# Altium schematic sheet pixracer_fmu.SchDoc(pixracer_fmu)
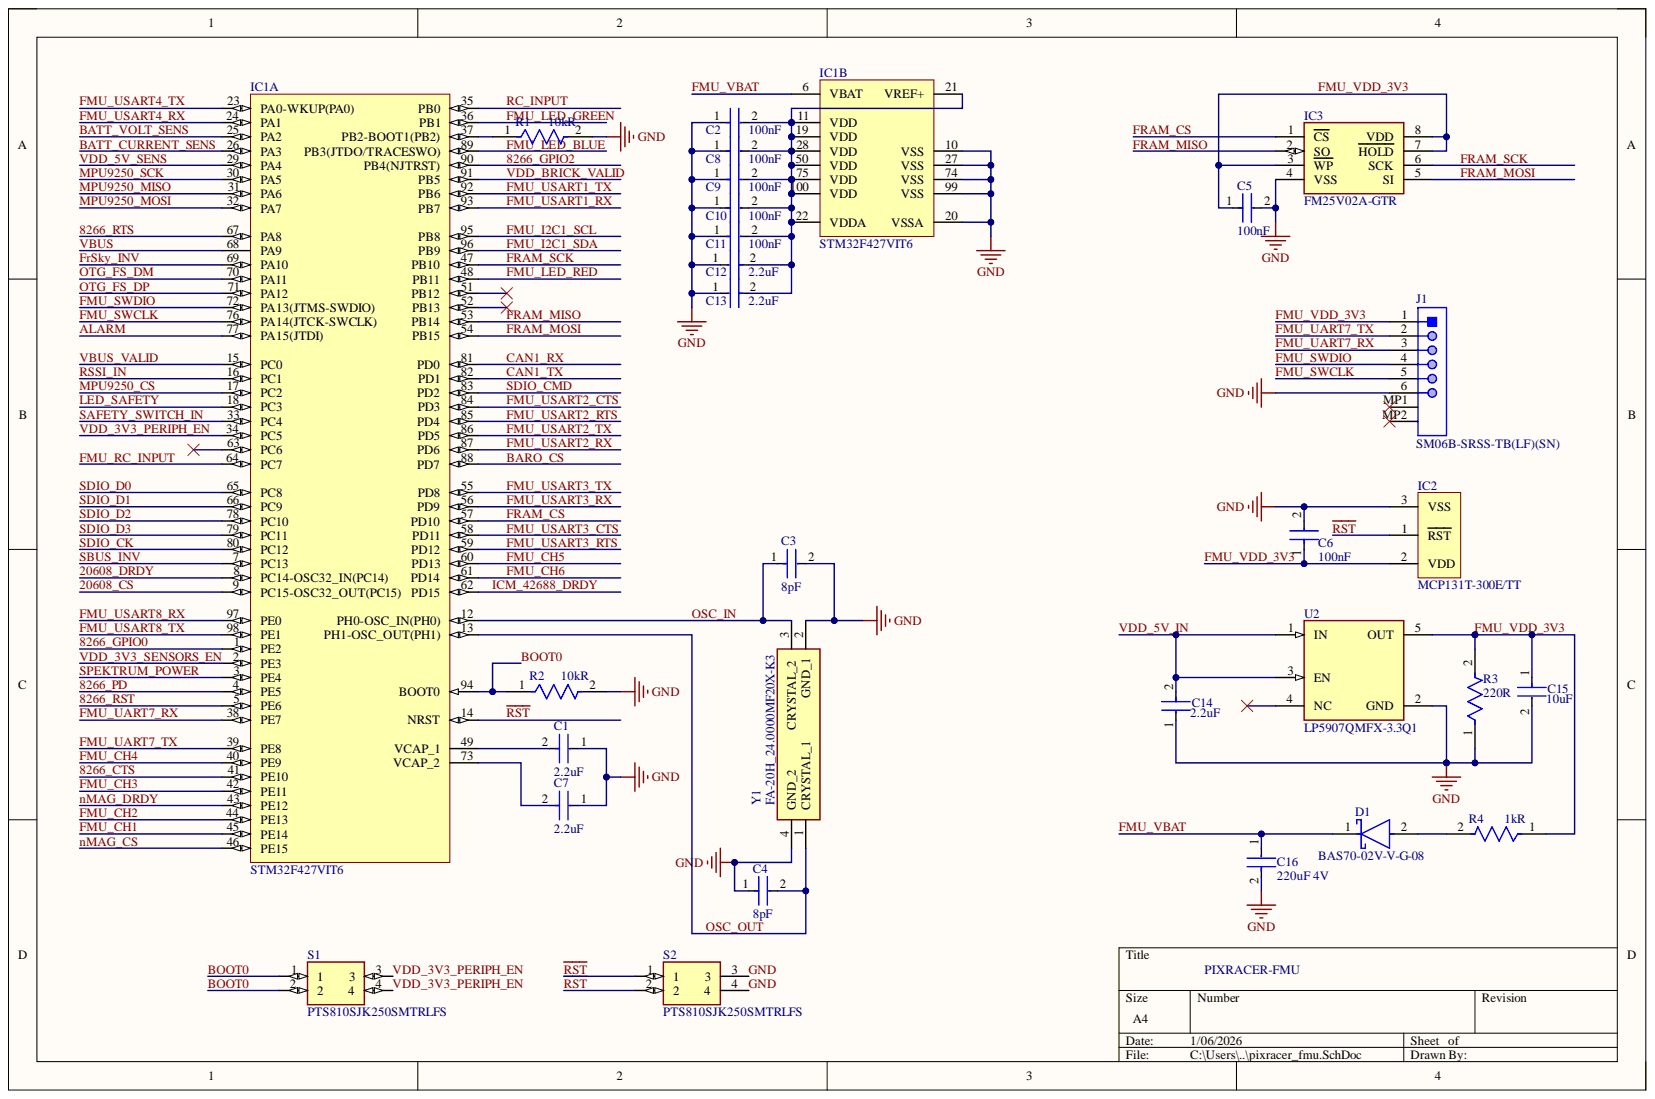
[diagram p0: pixracer_fmu.SchDoc]

## Parts
C1  Capacitance: 2.2uF  [FP-C1608-080-0_1-MFG]  (hidden comment: C1608X7R1A225K080AC)
C2  Capacitance: 100nF  [FP-0603-L_1_6_0_15-W_0_81-IPC_B]  (hidden comment: 0603ZC104MAT2A)
C3  Capacitance: 8pF  [FP-CGA3E-0_3-0_1-IPC_A]  (hidden comment: CGA3E2C0G1H080D080AA)
C4  Capacitance: 8pF  [FP-CGA3E-0_3-0_1-IPC_A]  (hidden comment: CGA3E2C0G1H080D080AA)
C5  Capacitance: 100nF  [FP-0603-L_1_6_0_15-W_0_81-IPC_B]  (hidden comment: 0603ZC104MAT2A)
C6  Capacitance: 100nF  [FP-0603-L_1_6_0_15-W_0_81-IPC_B]  (hidden comment: 0603ZC104MAT2A)
C7  Capacitance: 2.2uF  [FP-C1608-080-0_1-MFG]  (hidden comment: C1608X7R1A225K080AC)
C8  Capacitance: 100nF  [FP-0603-L_1_6_0_15-W_0_81-IPC_B]  (hidden comment: 0603ZC104MAT2A)
C9  Capacitance: 100nF  [FP-0603-L_1_6_0_15-W_0_81-IPC_B]  (hidden comment: 0603ZC104MAT2A)
C10  Capacitance: 100nF  [FP-0603-L_1_6_0_15-W_0_81-IPC_B]  (hidden comment: 0603ZC104MAT2A)
C11  Capacitance: 100nF  [FP-0603-L_1_6_0_15-W_0_81-IPC_B]  (hidden comment: 0603ZC104MAT2A)
C12  Capacitance: 2.2uF  [FP-C1608-080-0_1-MFG]  (hidden comment: C1608X7R1A225K080AC)
C13  Capacitance: 2.2uF  [FP-C1608-080-0_1-MFG]  (hidden comment: C1608X7R1A225K080AC)
C14  Capacitance: 2.2uF  [FP-C1608-080-0_1-MFG]  (hidden comment: C1608X7R1A225K080AC)
C15  Capacitance: 10uF  [FP-CL10-IPC_B]  (hidden comment: CL10A106KP8NNND)
C16  GRM31CR60G227ME11L  [FP-GRM31C-0_3-e0_3_0_9-IPC_C]  (value not drawn)
D1  BAS70-02V-V-G-08  [FP-S8-V-3880_02-001-MFG]
IC1  STM32F427VIT6  [STM-LQFP100_N]  (parts A,B)
IC2  MCP131T-300E/TT  [SOT-23-TT3_N]
IC3  FM25V02A-GTR  [SOIC127P602X173-8N]
J1  SM06B-SRSS-TB(LF)(SN)  [JST-SM06B-SRSS-TB_V]
R1  Resistance: 10kR  [FP-ERJ3G-IPC_C]  (hidden comment: ERJ-3GEYJ103V)
R2  Resistance: 10kR  [FP-ERJ3G-IPC_C]  (hidden comment: ERJ-3GEYJ103V)
R3  Resistance: 220R  [FP-CRCW0603-e3-MFG]  (hidden comment: CRCW0603220RFKEB)
R4  Resistance: 1kR  [FP-RC1608-IPC_A]  (hidden comment: RC1608J102CS)
S1  PTS810SJK250SMTRLFS  [PTS810SJG250SMTRLFS]
S2  PTS810SJK250SMTRLFS  [PTS810SJG250SMTRLFS]
U2  LP5907QMFX-3.3Q1  [FP-DBV0005A-MFG]
Y1  FA-20H_24.0000MF20X-K3  [FA20H160000MF12ZAC3]

## Nets
8266_CTS: IC1.41(PE10)
8266_GPIO0: IC1.1(PE2)
8266_GPIO2: IC1.90(PB4(NJTRST))
8266_PD: IC1.4(PE5)
8266_RST: IC1.5(PE6)
8266_RTS: IC1.67(PA8)
20608_CS: IC1.9(PC15-OSC32_OUT(PC15))
20608_DRDY: IC1.8(PC14-OSC32_IN(PC14))
~{RST}: IC1.14(NRST) IC2.1 S2.1 S2.2
ALARM: IC1.77(PA15(JTDI))
BARO_CS: IC1.88(PD7)
BATT_CURRENT_SENS: IC1.26(PA3)
BATT_VOLT_SENS: IC1.25(PA2)
BOOT0: IC1.94(BOOT0) R2.1 S1.1 S1.2
CAN1_RX: IC1.81(PD0)
CAN1_TX: IC1.82(PD1)
FMU_CH1: IC1.45(PE14)
FMU_CH2: IC1.44(PE13)
FMU_CH3: IC1.42(PE11)
FMU_CH4: IC1.40(PE9)
FMU_CH5: IC1.60(PD13)
FMU_CH6: IC1.61(PD14)
FMU_I2C1_SCL: IC1.95(PB8)
FMU_I2C1_SDA: IC1.96(PB9)
FMU_LED_BLUE: IC1.89(PB3(JTDO/TRACESWO))
FMU_LED_GREEN: IC1.36(PB1)
FMU_LED_RED: IC1.48(PB11)
FMU_RC_INPUT: IC1.64(PC7)
FMU_SWCLK: IC1.76(PA14(JTCK-SWCLK)) J1.5
FMU_SWDIO: IC1.72(PA13(JTMS-SWDIO)) J1.4
FMU_UART7_RX: IC1.38(PE7) J1.3
FMU_UART7_TX: IC1.39(PE8) J1.2
FMU_USART1_RX: IC1.93(PB7)
FMU_USART1_TX: IC1.92(PB6)
FMU_USART2_CTS: IC1.84(PD3)
FMU_USART2_RTS: IC1.85(PD4)
FMU_USART2_RX: IC1.87(PD6)
FMU_USART2_TX: IC1.86(PD5)
FMU_USART3_CTS: IC1.58(PD11)
FMU_USART3_RTS: IC1.59(PD12)
FMU_USART3_RX: IC1.56(PD9)
FMU_USART3_TX: IC1.55(PD8)
FMU_USART4_RX: IC1.24(PA1)
FMU_USART4_TX: IC1.23(PA0-WKUP(PA0))
FMU_USART8_RX: IC1.97(PE0)
FMU_USART8_TX: IC1.98(PE1)
FMU_VBAT: C16.1 D1.1 IC1.6(VBAT)
FMU_VDD_3V3: C5.1 C6.1 C15.1 IC2.2 IC3.3(WP) IC3.7(HOLD) IC3.8(VDD) J1.1 R3.2 R4.1 U2.5(OUT)
FRAM_CS: IC1.57(PD10) IC3.1(CS)
FRAM_MISO: IC1.53(PB14) IC3.2(SO)
FRAM_MOSI: IC1.54(PB15) IC3.5(SI)
FRAM_SCK: IC1.47(PB10) IC3.6(SCK)
FrSky_INV: IC1.69(PA10)
GND: C1.1 C2.1 C3.2 C4.1 C5.2 C6.2 C7.1 C8.1 C9.1 C10.1 C11.1 C12.1 C13.1 C14.1 C15.2 C16.2 IC1.10(VSS) IC1.20(VSSA) IC1.27(VSS) IC1.74(VSS) IC1.99(VSS) IC2.3 IC3.4(VSS) J1.6 R1.2 R2.2 R3.1 S2.3 S2.4 U2.2(GND) Y1.2(GND_1) Y1.4(GND_2)
ICM_42688_DRDY: IC1.62(PD15)
LED_SAFETY: IC1.18(PC3)
MPU9250_CS: IC1.17(PC2)
MPU9250_MISO: IC1.31(PA6)
MPU9250_MOSI: IC1.32(PA7)
MPU9250_SCK: IC1.30(PA5)
nMAG_CS: IC1.46(PE15)
nMAG_DRDY: IC1.43(PE12)
OSC_IN: C3.1 IC1.12(PH0-OSC_IN(PH0)) Y1.3(CRYSTAL_2)
OSC_OUT: C4.2 IC1.13(PH1-OSC_OUT(PH1)) Y1.1(CRYSTAL_1)
OTG_FS_DM: IC1.70(PA11)
OTG_FS_DP: IC1.71(PA12)
RC_INPUT: IC1.35(PB0)
RSSI_IN: IC1.16(PC1)
SAFETY_SWITCH_IN: IC1.33(PC4)
SBUS_INV: IC1.7(PC13)
SDIO_CK: IC1.80(PC12)
SDIO_CMD: IC1.83(PD2)
SDIO_D0: IC1.65(PC8)
SDIO_D1: IC1.66(PC9)
SDIO_D2: IC1.78(PC10)
SDIO_D3: IC1.79(PC11)
SPEKTRUM_POWER: IC1.3(PE4)
VBUS: IC1.68(PA9)
VBUS_VALID: IC1.15(PC0)
VDD_3V3_PERIPH_EN: IC1.34(PC5) S1.3 S1.4
VDD_3V3_SENSORS_EN: IC1.2(PE3)
VDD_5V_IN: C14.2 U2.1(IN) U2.3(EN)
VDD_5V_SENS: IC1.29(PA4)
VDD_BRICK_VALID: IC1.91(PB5)
NetC1_2: C1.2 IC1.49(VCAP_1)
NetC2_2: C2.2 C8.2 C9.2 C10.2 C11.2 C12.2 C13.2 IC1.11(VDD) IC1.19(VDD) IC1.21(VREF+) IC1.22(VDDA) IC1.28(VDD) IC1.50(VDD) IC1.75(VDD) IC1.100(VDD)
NetC7_2: C7.2 IC1.73(VCAP_2)
NetD1_2: D1.2 R4.2
NetIC1_37: IC1.37(PB2-BOOT1(PB2)) R1.1

Not connected: IC1 51(PB12) 52(PB13) 63(PC6); J1 MP1 MP2; U2 4(NC)

## Library data of the parts
C1 C7 C12-C14 = CMP-08246-006835-1: Multilayer Ceramic Capacitors 2.2μF ±10% 10V X7R SMD 0603; Case Code (Imperial): 0603; Case Code (Metric): 1608; Case/Package: 0603; Component Kind: Standard; Construction: Flat; Depth: 0.8mm; Dielectric: X7R; Dielectric Material: Ceramic; Height: 0.8mm; Lead Free: Lead Free; Length: 1.6mm; Library Name: Altium Content Vault; Max Operating Temperature: 125°C; Min Operating Temperature: -55°C; Mount: Surface Mount; Package Quantity: 4000; Packaging: Tape and Reel; REACH SVHC: No SVHC; Resistor Type: Ceramic; RoHS Compliant: Yes; Series: C; Termination: SMD/SMT; Thickness: 0.037inch; Tolerance: 10%; Voltage Rating: 10V; Voltage Rating (DC): 10V; Weight: 7.1E-05oz; Width: 0.031inch
C2 C5 C6 C8-C11 = CMP-2006-04550-2: General Purpose Ceramic Capacitor, 0603, 100nF, 20%, X7R, 15%, 10V; Case Code (Imperial): 0603; Case Code (Metric): 1608; Case/Package: 0603; Component Kind: Standard; Construction: Flat; Depth: 0.81mm; Dielectric: X7R; Lead Free: Lead Free; Lead Pitch: 0.014inch; Length: 1.6mm; Library Name: Altium Content Vault; Max Operating Temperature: 125°C; Min Operating Temperature: -55°C; Mount: Surface Mount; Number of Pins: 2; Package Quantity: 4000; Packaging: Tape and Reel; Radiation Hardening: No; RoHS Compliant: Yes; Series: -; Termination: SMD/SMT; Thickness: 0.035inch; Tolerance: 20%; Voltage Rating: 10V; Voltage Rating (DC): 10V; Width: 0.032inch
C3 C4 = CMP-08246-000516-1: Cap Ceramic 8pF 50V C0G 0.5pF Pad SMD 0603 +125°C Automotive T/R; Case Code (Imperial): 0603; Case Code (Metric): 1608; Case/Package: 0603; Component Kind: Standard; Composition: Ceramic; Depth: 0.8mm; Dielectric: C0G; Height: 0.8mm; Length: 1.6mm; Library Name: Altium Content Vault; Max Operating Temperature: 125°C; Min Operating Temperature: -55°C; Mount: Surface Mount; Package Quantity: 4000; Packaging: Tape and Reel; Radiation Hardening: No; Ratings: AEC-Q200; REACH SVHC: No SVHC; Termination: SMD/SMT; Thickness: 0.035inch; Voltage Rating: 50V; Voltage Rating (DC): 50V; Weight: 7.1E-05oz; Width: 0.031inch
C15 = CMP-13271-003245-1: Cap Ceramic 10uF 10V X5R ±10% Pad SMD 0603 +85°C T/R; Case/Package: 0603; Component Kind: Standard; Depth: 0.8mm; Dielectric: X5R; Height: 0.8mm; Lead Free: Lead Free; Length: 1.6mm; Library Name: Altium Content Vault; Max Operating Temperature: 85°C; Min Operating Temperature: -55°C; Mount: Surface Mount; Package Quantity: 10000; Packaging: Tape and Reel; Radiation Hardening: No; Thickness: 0.035inch; Tolerance: 10%; Voltage Rating: 10V; Voltage Rating (DC): 10V; Width: 0.031inch
C16 = CMP-06035-014715-1: Chip Multilayer Ceramic Capacitors for General Purpose, 1206, 220uF, X5R, 15%, 20%, 4V; Capacitance: 220uF 4V; Case Code (Imperial): 1206; Case Code (Metric): 3216; Case/Package: 1206; Component Kind: Standard; Dielectric: X5R; Height: 1.6mm; Lead Free: Lead Free; Length: 0.126inch; Library Name: Altium Content Vault; Max Operating Temperature: 85°C; Min Operating Temperature: -55°C; Mount: Surface Mount; Package Quantity: 2000; Packaging: Tape and Reel; REACH SVHC: No SVHC; Termination: SMD/SMT; Thickness: 0.075inch; Tolerance: 20%; Voltage Rating: 4V; Voltage Rating (DC): 4V; Weight: 0.001411oz; Width: 1.6mm
D1 = CMP-02539-000029-1: DIODE SCHOTTKY 70V 100MA SOD523; Manufacturer: Vishay; Capacitance: 1.5pF; Max Junction Temp: +125°C; Max Surge Forward Current: 600mA; Peak Reverse Current: 0.02uA; Value: 1.5pF; Automotive: No; Category: Diode; Component Kind: Standard; ComponentLink2Description: Datasheet; ComponentLink2URL: https://www.vishay.com/docs/82393/bas7002v.pdf; Device Class L1: Discrete Semiconductors; Device Class L2: Diodes; Device Class L3: Schottky Diodes; Digikey Description: DIODE SCHOTTKY 70V 100MA SOD523; Forward Current: 100mA; Height: 0.7mm; Ipc Land Pattern Name: SODFL16080X60; Lead Free: Yes; Library Name: Altium Content Vault; Manufacturer Part Number: BAS70-02V-V-G-08; Max Repetitive Reverse Voltage: 70V; Mouser Description: Schottky Diodes & Rectifiers SCHOTTKY DIODE, PLASTIC; Octopart: https://octopart.com/bas70-02v-v-g-08-vishay-44351226; Package: S8-V-3880.02-001; Reverse Voltage: 70V; RoHS: TRUE; Temperature: to +125°C; Temperature Range High: +125°C
IC1 = CMP-0243-00027-2: ARM Cortex-M4 32-bit MCU+FPU, 210 DMIPS, 2048 kB Flash, 256 kB Internal RAM, 82 I/Os, 100-pin LQFP, -40 to 85 degC, Tray; Manufacturer: STMicroelectronics; D/A Converter: 2x12-bit; FLASH Size (Prog) (kB): 2048; I/Os (High Current): 82; Internal RAM Size (kB): 256; Operating Frequency(F) (Processor speed) (MHz): 168; Supply Voltage(Vcc) max (V): 3.6; Supply Voltage(Vcc) min (V): 1.8; Component Kind: Standard; ComponentLink1Description: Manufacturer URL; ComponentLink1URL: http://www.st.com/web/en/home.html; ComponentLink2Description: Datasheet; ComponentLink2URL: http://www.st.com/st-web-ui/static/active/en/resource/technical/document/datasheet/DM00071990.pdf; ComponentLink3Description: Package Specification; ComponentLink3URL: http://www.st.com/st-web-ui/static/active/en/resource/technical/document/data_brief/DM00071938.pdf; Core: ARM Cortex-M4; DatasheetVersion: Rev. 1; Library Name: Altium Content Vault; Mounting Technology: Surface Mount; PackageDescription: 100-Pin Low Profile Quad Flat Package, 14 x 14 mm Body, 0.5 mm Pitch; PackageReference: LQFP100; PackageVersion: Rev. 1, 06/2013; Packing: Tray; PartNumber: STM32F427VIT6
IC2 = CMP-0138-00370-1: Micropower Voltage Supervisors, 3-Pin SOT-23, Extended Temperature, Tape and Reel; Code_IPC: SOT23-3; Component Kind: Standard; DatasheetVersion: revB, Mar-2005; Library Name: Altium Content Vault; PackageDescription: 3-Lead Plastic Small Outline Transistor (TT) [SOT-23]; PackageReference: SOT-23-TT3; PackageVersion: revBB, Aug-2009
IC3 = FM25V02A-GTR: Integrated Circuit; Manufacturer_Name: Cypress Semiconductor; Arrow Part Number: FM25V02A-GTR; Arrow Price/Stock: https://www.arrow.com/en/products/fm25v02a-gtr/cypress-semiconductor?region=nac; Component Kind: Standard; Datasheet Link: https://www.cypress.com/file/139666/download; Height: 1.727mm; Manufacturer_Part_Number: FM25V02A-GTR; Mouser Part Number: 727-FM25V02A-GTR; Mouser Price/Stock: https://www.mouser.co.uk/ProductDetail/Cypress-Semiconductor/FM25V02A-GTR?qs=ILgNtqsyH21Sja70fv%2FiBQ%3D%3D
J1 = CMP-2000-07080-1: Male Header, Pitch 1 mm, 1 x 6 Position, Height 2.95 mm, -25 to 85 degC, RoHS, Tape and Reel; Manufacturer: JST; No of Positions: 6; Pitch: 1mm; Style/Orientation: RightAngleHeader/Plug; Component Kind: Standard; Datasheet URL: https://datasheet.ciiva.com/26894/getdatasheetpartid-708885-26894671.pdf; Datasheet Version: 11/2010; Library Name: Altium Content Vault; Manufacturer URL: http://www.jst.com/home2.html; Mounting Technology: SurfaceMount; Package Description: Male Header, Pitch 1 mm, 1 X 6 Position, Height 2.9 mm; Package Reference: SM06B-SRSS-TB; Termination: Solder; Type: ShroudedHeader
R1 R2 = CMP-2000-00704-2: RES SMD 10K OHM 5% 1/10W 0603; Max Power Dissipation: 100mW; Temperature Coefficient: 200ppm/°C; Voltage Rating: 75V; Voltage Rating (DC): 50V; Case Code (Imperial): 0603; Case Code (Metric): 1608; Case/Package: 0603; Component Kind: Standard; Construction: Rectangular; Contact Plating: Tin; Depth: 0.8mm; Features: Automotive AEC-Q200; Height: 0.45mm; Lead Free: Lead Free; Length: 1.6mm; Library Name: Altium Content Vault; Max Operating Temperature: 155°C; Military Standard: Not; Min Operating Temperature: -55°C; Mount: Surface Mount; Number of Terminations: 2; Package Quantity: 1; Packaging: Tape and Reel; Power Rating: 100mW; REACH SVHC: No SVHC; Resistance Tolerance: 5%; Resistor Type: Thick Film; RoHS Compliant: Yes; Series: ERJ; Technology: THICK FILM; Termination: SMD/SMT; Tolerance: 5%; Weight: 7.1E-05oz; Width: 0.8mm; Working Voltage: 75V
R3 = CMP-02407-003555-1: RES Thick Film, 220Ω, 1%, 0.1W, 100ppm/°C, 0603; Max Power Dissipation: 100mW; Voltage Rating: 75V; Case Code (Imperial): 0603; Case Code (Metric): 1608; Case/Package: 0603; Component Kind: Standard; Composition: Thick Film; Depth: 0.85mm; Features: Automotive AEC-Q200; Height: 0.02inch; Lead Free: Lead Free; Length: 1.55mm; Library Name: Altium Content Vault; Max Operating Temperature: 155°C; Military Standard: Not; Min Operating Temperature: -55°C; Number of Terminations: 2; Package Quantity: 10000; Packaging: Tape and Reel; Power Rating: 100mW; Temperature Coefficient: 100ppm/°C; Termination: SMD/SMT; Tolerance: 1%; Weight: 7.1E-05oz; Width: 0.85mm
R4 = CMP-13261-002301-1: Res Thick Film 0603 1kΩ 5% 0.1W ±100ppm/°C Molded SMD T/R; Max Power Dissipation: 100mW; Voltage Rating (DC): 50V; Case Code (Imperial): 0603; Case Code (Metric): 1608; Case/Package: 0603; Component Kind: Standard; Composition: Thick Film; Contact Plating: Tin; Depth: 0.8mm; Features: Moisture Resistant; Height: 0.022inch; Lead Free: Lead Free; Length: 1.6mm; Library Name: Altium Content Vault; Max Operating Temperature: 155°C; Military Standard: Not; Min Operating Temperature: -55°C; Mount: Surface Mount; Number of Terminations: 2; Packaging: Tape and Reel; Power Rating: 100mW; Radiation Hardening: No; Temperature Coefficient: 100ppm/°C; Tolerance: 5%; Width: 0.031inch
S1 S2 = PTS810SJK250SMTRLFS: Tactile Switch SPST-NO Top Actuated Surface Mount; MF: C&K; Check_prices: https://www.snapeda.com/parts/PTS810%20SJK%20250%20SMTR%20LFS/C%2526K/view-part/?ref=eda; Component Kind: Standard; Library Name: PTS810SJK250SMTRLFS.IntLib; MP: PTS810 SJK 250 SMTR LFS; Package: None; SnapEDA_Link: https://www.snapeda.com/parts/PTS810%20SJK%20250%20SMTR%20LFS/C%2526K/view-part/?ref=snap
U2 = CMP-04917-000018-1: IC REG LINEAR 3.3V 250MA SOT23-5; Manufacturer: Texas Instruments; Automotive Grade: Grade 1; Dropout Voltage: 120mV; Max Junction Temp: +125°C; Max Supply Voltage: 5.5V; Min Supply Voltage: 2.2V; Nominal Supply Current: 12-250uA; Number Of Outputs: 1; Output Type: Fixed; Standoff Height: 0mm; Automotive: Yes; Category: IC; Component Kind: Standard; ComponentLink2Description: Datasheet; ComponentLink2URL: http://www.ti.com/lit/ds/symlink/lp5907-q1.pdf; Device Class L1: Integrated Circuits (ICs); Device Class L2: Power Management ICs; Device Class L3: Voltage Regulators - Linear; Digikey Description: IC REG LINEAR 3.3V 250MA SOT23-5; Height: 1.45mm; Lead Free: Yes; Library Name: Altium Content Vault; Manufacturer Part Number: LP5907QMFX-3.3Q1; Mouser Description: Automotive 250-mA ultra-low-noise low-IQ low-dropout (LDO) linear regulator 5-SOT-23 -40 to 125; Octopart: https://octopart.com/lp5907qmfx-3.3q1-texas+instruments-50417899; Output Current: 250mA; Output Voltage: 3.3V; Package: DBV0005A; RoHS: TRUE; Temperature: -40°C to +125°C; Temperature Range High: +125°C; Temperature Range Low: -40°C
Y1 = FA-20H_24.0000MF20X-K3: Crystal or Oscillator; Manufacturer_Name: Epson Timing; Manufacturer_Part_Number: FA-20H 24.0000MF20X-K3; Component Kind: Standard; Datasheet Link: https://support.epson.biz/td/api/doc_check.php?dl=brief_FA-20H&lang=en; Height: 0.55mm; Mouser Part Number: 732-FA20H-24F20X-K3; Mouser Price/Stock: https://www.mouser.co.uk/ProductDetail/Epson-Timing/FA-20H-240000MF20X-K3?qs=CANAagUtPAp2w31393daZQ%3D%3D
